# Altium schematic sheet [2]V3S_Power_System.SchDoc
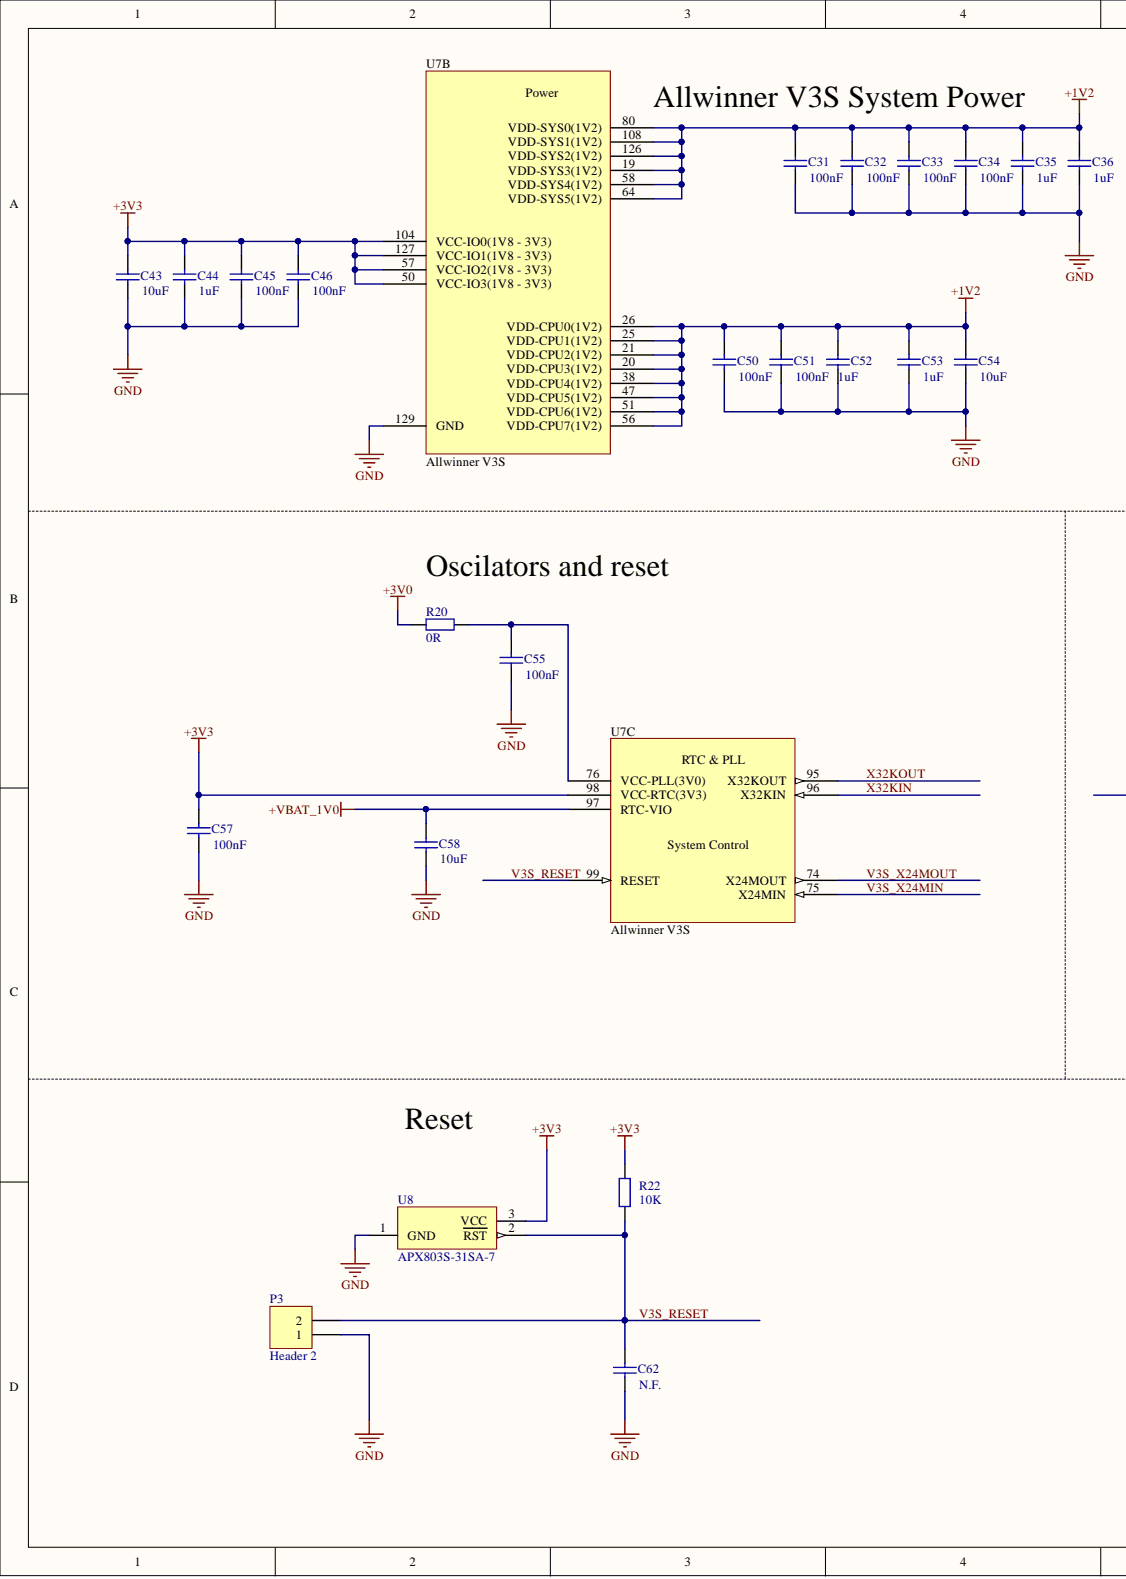
[diagram p0: [2]V3S_Power_System.SchDoc, left]
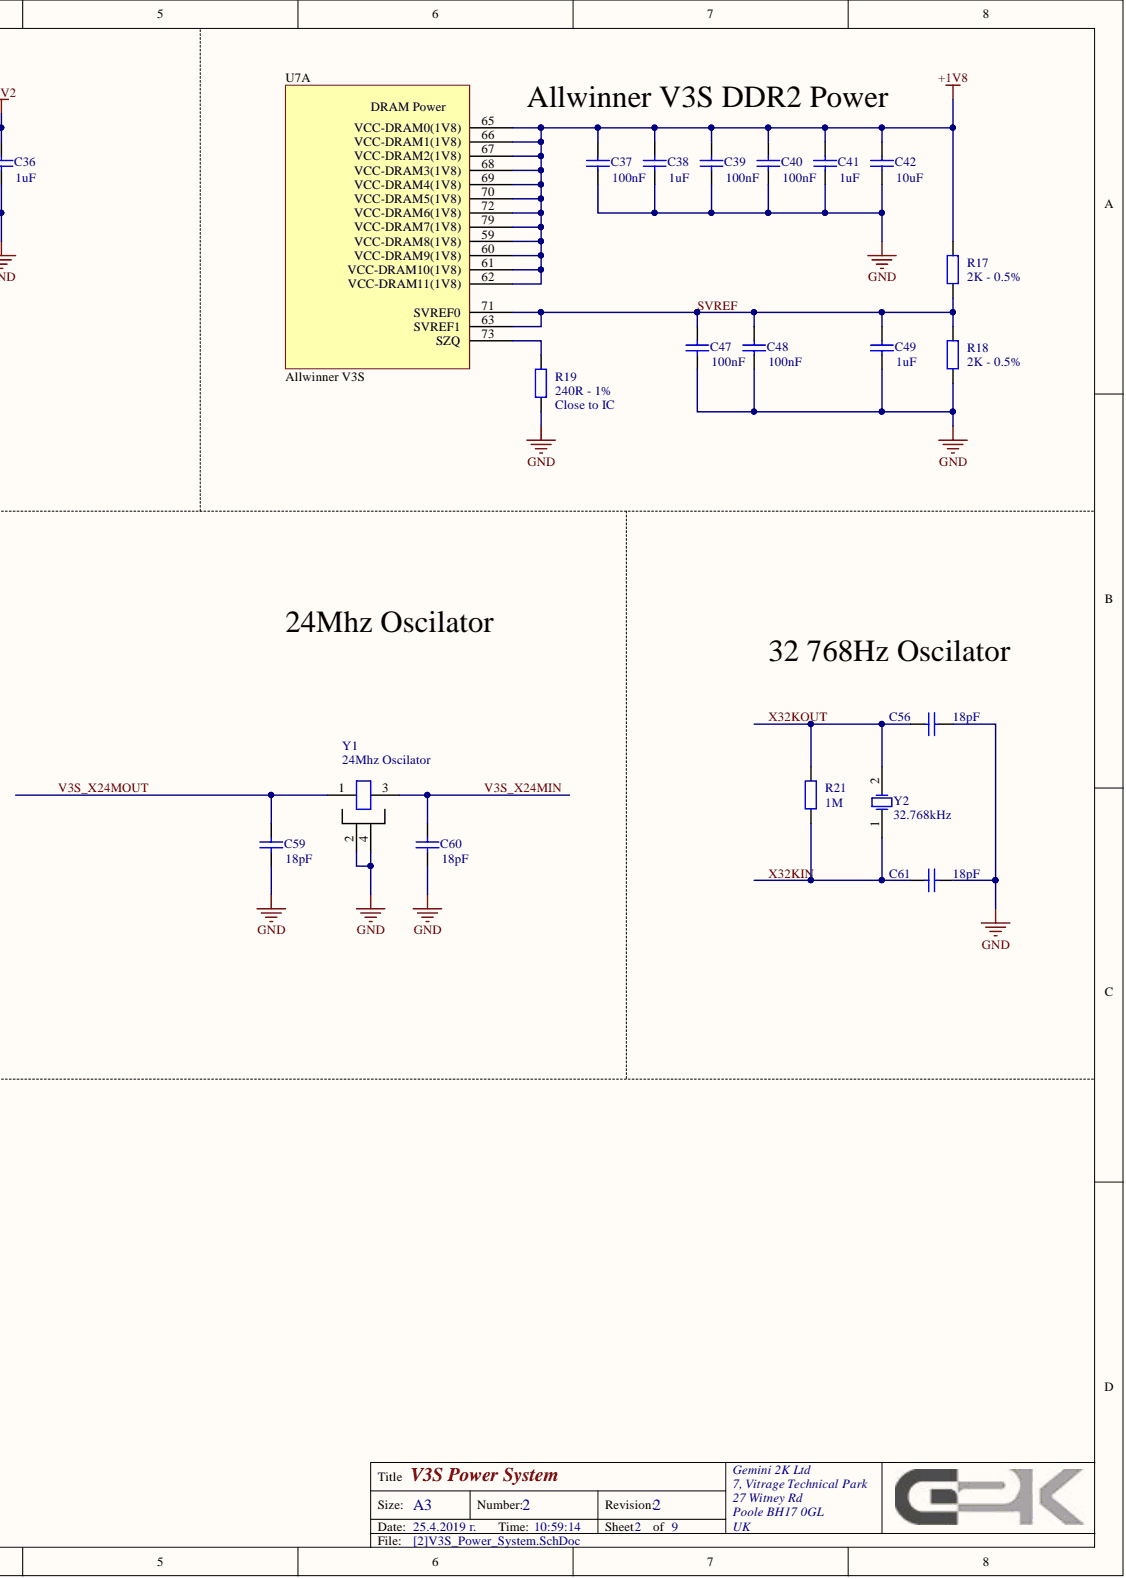
[diagram p1: [2]V3S_Power_System.SchDoc, right]

## Parts
C31  value 100nF  [1608[0603]]  (hidden comment: 0603)
C32  value 100nF  [1608[0603]]  (hidden comment: 0603)
C33  value 100nF  [1608[0603]]  (hidden comment: 0603)
C34  value 100nF  [1608[0603]]  (hidden comment: 0603)
C35  value 1uF  [1608[0603]]  (hidden comment: 0603)
C36  value 1uF  [1608[0603]]  (hidden comment: 0603)
C37  value 100nF  [1608[0603]]  (hidden comment: 0603)
C38  value 1uF  [1608[0603]]  (hidden comment: 0603)
C39  value 100nF  [1608[0603]]  (hidden comment: 0603)
C40  value 100nF  [1608[0603]]  (hidden comment: 0603)
C41  value 1uF  [1608[0603]]  (hidden comment: 0603)
C42  value 10uF  [1608[0603]]  (hidden comment: 0603)
C43  value 10uF  [1608[0603]]  (hidden comment: 0603)
C44  value 1uF  [1608[0603]]  (hidden comment: 0603)
C45  value 100nF  [1608[0603]]  (hidden comment: 0603)
C46  value 100nF  [1608[0603]]  (hidden comment: 0603)
C47  value 100nF  [1608[0603]]  (hidden comment: 0603)
C48  value 100nF  [1608[0603]]  (hidden comment: 0603)
C49  value 1uF  [1608[0603]]  (hidden comment: 0603)
C50  value 100nF  [1608[0603]]  (hidden comment: 0603)
C51  value 100nF  [1608[0603]]  (hidden comment: 0603)
C52  value 1uF  [1608[0603]]  (hidden comment: 0603)
C53  value 1uF  [1608[0603]]  (hidden comment: 0603)
C54  value 10uF  [1608[0603]]  (hidden comment: 0603)
C55  value 100nF  [1608[0603]]  (hidden comment: 0603)
C56  value 18pF  [1608[0603]]  (hidden comment: 0603)
C57  value 100nF  [1608[0603]]  (hidden comment: 0603)
C58  value 10uF  [1608[0603]]  (hidden comment: 0603)
C59  value 18pF  [1608[0603]]  (hidden comment: 0603)
C60  value 18pF  [1608[0603]]  (hidden comment: 0603)
C61  value 18pF  [1608[0603]]  (hidden comment: 0603)
C62  value N.F.  [1608[0603]]  (hidden comment: 0603)
P3  Header 2  [HDR1X2]
R17  value 2K - 0.5%  [J1-0603]  (hidden comment: 0603)
R18  value 2K - 0.5%  [J1-0603]  (hidden comment: 0603)
R19  value 240R - 1%  [J1-0603]  (hidden comment: 0603)
R20  value 0R  [J1-0603]  (hidden comment: 0603)
R21  value 1M  [J1-0603]  (hidden comment: 0603)
R22  value 10K  [J1-0603]  (hidden comment: 0603)
U7  Allwinner V3S  Manufacturer Name: Allwinner  Manufacturer Part No.: V3S  [LQFP128 E-PAD - Low Density]  (parts A,B,C)
U8  APX803S-31SA-7  [RT-3]
Y1  24Mhz Oscilator  [SMD Crysta 2 x 1.6]
Y2  32.768kHz  [ABS07]

## Nets
+1V2: C31.2 C32.2 C33.2 C34.2 C35.2 C36.2 C50.2 C51.2 C52.2 C53.2 C54.2 U7.19(VDD-SYS3(1V2)) U7.20(VDD-CPU3(1V2)) U7.21(VDD-CPU2(1V2)) U7.25(VDD-CPU1(1V2)) U7.26(VDD-CPU0(1V2)) U7.38(VDD-CPU4(1V2)) U7.47(VDD-CPU5(1V2)) U7.51(VDD-CPU6(1V2)) U7.56(VDD-CPU7(1V2)) U7.58(VDD-SYS4(1V2)) U7.64(VDD-SYS5(1V2)) U7.80(VDD-SYS0(1V2)) U7.108(VDD-SYS1(1V2)) U7.126(VDD-SYS2(1V2))
+1V8: C37.2 C38.2 C39.2 C40.2 C41.2 C42.2 R17.2 U7.59(VCC-DRAM8(1V8)) U7.60(VCC-DRAM9(1V8)) U7.61(VCC-DRAM10(1V8)) U7.62(VCC-DRAM11(1V8)) U7.65(VCC-DRAM0(1V8)) U7.66(VCC-DRAM1(1V8)) U7.67(VCC-DRAM2(1V8)) U7.68(VCC-DRAM3(1V8)) U7.69(VCC-DRAM4(1V8)) U7.70(VCC-DRAM5(1V8)) U7.72(VCC-DRAM6(1V8)) U7.79(VCC-DRAM7(1V8))
+3V0: R20.2
+3V3: C43.2 C44.2 C45.2 C46.2 C57.2 R22.2 U7.50(VCC-IO3(1V8_-_3V3)) U7.57(VCC-IO2(1V8_-_3V3)) U7.98(VCC-RTC(3V3)) U7.104(VCC-IO0(1V8_-_3V3)) U7.127(VCC-IO1(1V8_-_3V3)) U8.3
+VBAT_1V0: C58.2 U7.97(RTC-VIO)
GND: C31.1 C32.1 C33.1 C34.1 C35.1 C36.1 C37.1 C38.1 C39.1 C40.1 C41.1 C42.1 C43.1 C44.1 C45.1 C46.1 C47.1 C48.1 C49.1 C50.1 C51.1 C52.1 C53.1 C54.1 C55.1 C56.1 C57.1 C58.1 C59.2 C60.2 C61.1 C62.1 P3.1 R18.1 R19.1 U7.129(GND) U8.1 Y1.2 Y1.4
SVREF: C47.2 C48.2 C49.2 R17.1 R18.2 U7.63(SVREF1) U7.71(SVREF0)
V3S_RESET: C62.2 P3.2 R22.1 U7.99(RESET) U8.2
V3S_X24MIN: C60.1 U7.75(X24MIN) Y1.3
V3S_X24MOUT: C59.1 U7.74(X24MOUT) Y1.1
X32KIN: C61.2 R21.2 U7.96(X32KIN) Y2.1
X32KOUT: C56.2 R21.1 U7.95(X32KOUT) Y2.2
NetC55_2: C55.2 R20.1 U7.76(VCC-PLL(3V0))
NetR19_2: R19.2 U7.73(SZQ)

## Library data of the parts
C31-C34 C37 C39 C40 C45-C48 C50 C51 C55 C57 C62 = Cap: Capacitor; Note: We have them in Bulgaria; Case Code (in): 0603; Component Kind: Standard; LatestRevisionDate: 17-Jul-2002; LatestRevisionNote: Re-released for DXP Platform.; Library Name: Miscellaneous Devices.IntLib; PackageDescription: Radial Cap, Thru-Hole; 2 Leads; 0.3 in Pin Spacing; PackageReference: RAD-0.3; Published: 8-Jun-2000; Signal Integrity: Cap; Simulation: CAP; Publisher: Altium Limited
  C31-C34 C37 C39 C40 C45-C48 C50 C51 C55 C57: Distributor Link: http://uk.farnell.com/kemet/c0603x104k4ractu/cap-mlcc-x7r-100nf-16v-0603/dp/1414027
C35 C36 C38 C41-C44 C49 C52-C54 C56 C58-C61 = Cap: Capacitor; Case Code (in): 0603; Component Kind: Standard; LatestRevisionDate: 17-Jul-2002; LatestRevisionNote: Re-released for DXP Platform.; Library Name: Miscellaneous Devices.IntLib; PackageDescription: Radial Cap, Thru-Hole; 2 Leads; 0.3 in Pin Spacing; PackageReference: RAD-0.3; Published: 8-Jun-2000; Signal Integrity: Cap; Simulation: CAP; Publisher: Altium Limited
  C35 C36 C38 C41 C44 C49 C52 C53: Distributor Link: https://eu.mouser.com/ProductDetail/Taiyo-Yuden/LMK107B7105KA-T?qs=sGAEpiMZZMvsSlwiRhF8qgJCQaFFkNE%2fsetabqB5pzo%3d
  C42 C43 C54 C58: Distributor Link: https://eu.mouser.com/ProductDetail/Taiyo-Yuden/JMK107ABJ106MA-T?qs=sGAEpiMZZMvsSlwiRhF8qtYrDJZp5VWM0KJHW32Kpw4hdLhJsFnoCA%3d%3d
  C56 C59-C61: Distributor Link: https://eu.mouser.com/ProductDetail/AVX/06035A180JAT4A?qs=sGAEpiMZZMvsSlwiRhF8qoLJPdUUh17q4jx5a%252bHkpSk%3d
P3 = Header 2: Header, 2-Pin; Component Kind: Standard; LatestRevisionDate: 17-Jul-2002; LatestRevisionNote: Re-released for DXP Platform.; Library Name: Miscellaneous Connectors.IntLib; Signal Integrity: Connector; Publisher: Altium Limited
R17 R18 R21 R22 = Res2: Resistor; Case Code (in): 0603; Component Kind: Standard; LatestRevisionDate: 17-Jul-2002; LatestRevisionNote: Re-released for DXP Platform.; Library Name: Miscellaneous Devices.IntLib; PackageDescription: Axial Device, Thru-Hole; 2 Leads; 0.4 in Pin Spacing; PackageReference: AXIAL-0.4; Published: 8-Jun-2000; Signal Integrity: Res; Simulation: RESISTOR; Publisher: Altium Limited
  R17 R18: Distributor Link: https://eu.mouser.com/ProductDetail/Susumu/RR0816P-202-D?qs=sGAEpiMZZMvdGkrng054t0uOsFOTJMhbb%252bJzvSFaqdc%3d
  R21: Distributor Link: https://uk.farnell.com/yageo/rc0603fr-071ml/res-1m-1-0-1w-0603-thick-film/dp/9238840
  R22: Distributor Link: http://uk.farnell.com/multicomp/mcwr06x1002ftl/res-thick-film-10k-1-0-1w-0603/dp/2447230
R19 = Res2: Resistor; Layout Note: Close to IC; Case Code (in): 0603; Component Kind: Standard; Distributor Link: https://eu.mouser.com/ProductDetail/Yageo/RC0603FR-07240RL?qs=sGAEpiMZZMvdGkrng054t8Tx25L%252bvTaRo%252bAG04BmAkk%3d; LatestRevisionDate: 17-Jul-2002; LatestRevisionNote: Re-released for DXP Platform.; Library Name: Miscellaneous Devices.IntLib; PackageDescription: Axial Device, Thru-Hole; 2 Leads; 0.4 in Pin Spacing; PackageReference: AXIAL-0.4; Published: 8-Jun-2000; Signal Integrity: Res; Simulation: RESISTOR; Publisher: Altium Limited
R20 = Res2: Resistor; Note: We have them in Bulgaria; Case Code (in): 0603; Component Kind: Standard; Distributor Link: http://uk.farnell.com/yageo/rc0603fr-070rl/res-thick-film-0r-1-0-1w-0603/dp/2309106; LatestRevisionDate: 17-Jul-2002; LatestRevisionNote: Re-released for DXP Platform.; Library Name: Miscellaneous Devices.IntLib; PackageDescription: Axial Device, Thru-Hole; 2 Leads; 0.4 in Pin Spacing; PackageReference: AXIAL-0.4; Published: 8-Jun-2000; Signal Integrity: Res; Simulation: RESISTOR; Publisher: Altium Limited
U8 = ADM809TART: Microprocessor Supervisory Circuit in 3-Pin SOT-23; Manufacturer Name: Diodes Incorporated; G2K_PN: G-IC-APX803-31SA-SOT-23-01; Component Kind: Standard; ComponentLink1Description: Manufacturer Link; ComponentLink1URL: http://www.analog.com/en/prod/0,,766_822_ADM809%2C00.html; ComponentLink2Description: Datasheet; ComponentLink2URL: http://www.analog.com/UploadedFiles/Data_Sheets/101131995ADM803_9_10_e.pdf; DatasheetVersion: REV.0 1997; Distributor Link: https://eu.mouser.com/ProductDetail/Diodes-Incorporated/APX803S-31SA-7?qs=%2Fha2pyFaduhebEAPwwFyerWu7K%252BS8dKoSHRSm1tt3Sf7eJGT%2FIrr6g%3D%3D; LatestRevisionDate: 17-Jul-2002; LatestRevisionNote: Re-released for DXP Platform.; Library Name: AD Power Mgt Supervisory Circuit.IntLib; Manufacturer Part No.: APX803S-31SA-7; PackageDescription: SOT-23; 3 Leads; Body 3.0 x 2.6 mm, inc. leads (LxW max); PackageReference: RT-3; PackageVersion: rev0; Price: .0; Price break at: 1000; Published: 8-Jun-2000; Value: APX803S-31SA-7; Publisher: Altium Limited
Y1 = XTAL: Manufacturer Name: AVX; Manufacturer Part No.: XRCGB24M000F2P00R0; Component Kind: Standard; Distributor Link: https://uk.farnell.com/murata/xrcgb24m000f2p00r0/crystal-24mhz-6pf-2-x-1-6mm/dp/2455832RL; Library Name: G2Pay_Lib.SchLib
Y2 = XTAL: Crystal Oscillator; Manufacturer Name: ABRACON; Manufacturer Part No.: ABS07-32.768KHZ-T; G2K_PN: G-CRYS-Q32.768K-3.2x1.5-01; Value: ABS07-32.768KHZ-T; Component Kind: Standard; Distributor Link: https://eu.mouser.com/ProductDetail/ABRACON/ABS07-32768KHZ-T?qs=%2fha2pyFadugN4hoomGn41l7KrmUVd52LRSNj8gLQ2%252bD39%252bRftJxjtQ%3d%3d; Library Name: Miscellaneous Devices.IntLib
U7 = Allwinner V3S: Component Kind: Standard; Library Name: Allwinner.SchLib
